# Altium schematic sheet BREEZE Pro ESC-A V1.SchDoc
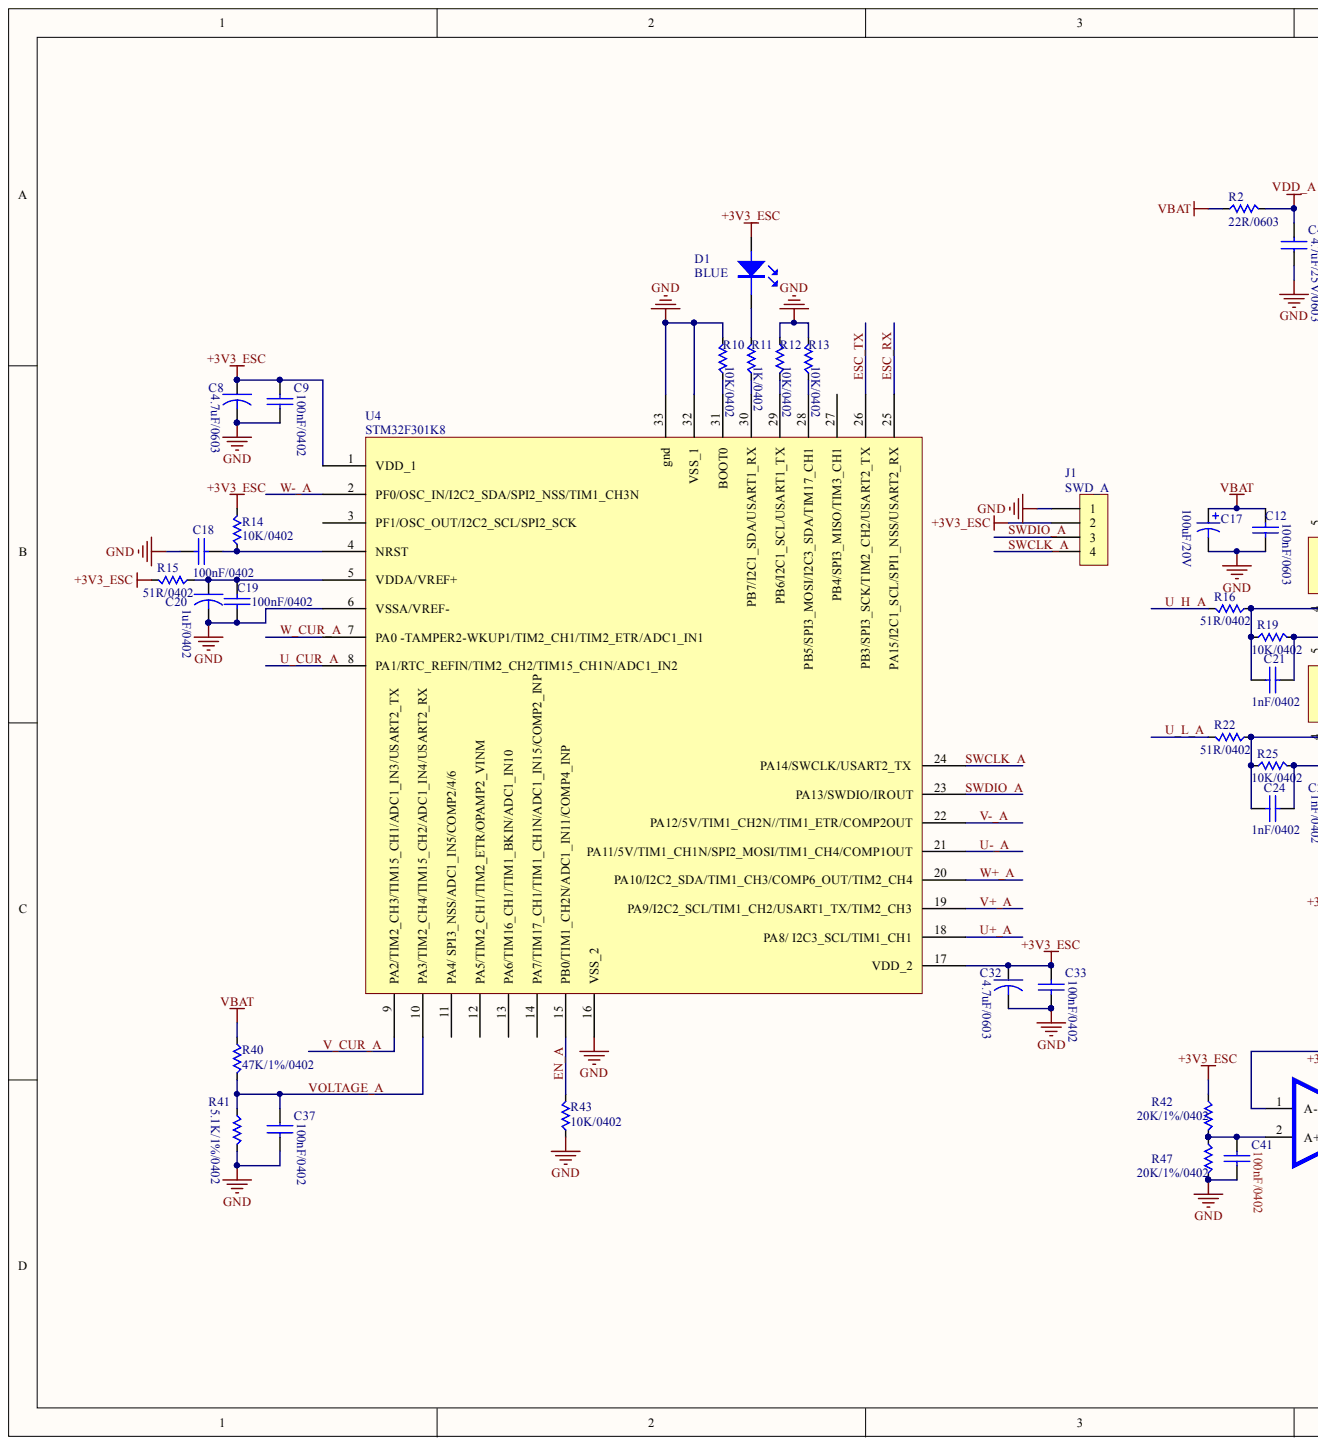
[diagram p0: BREEZE Pro ESC-A V1.SchDoc, left]
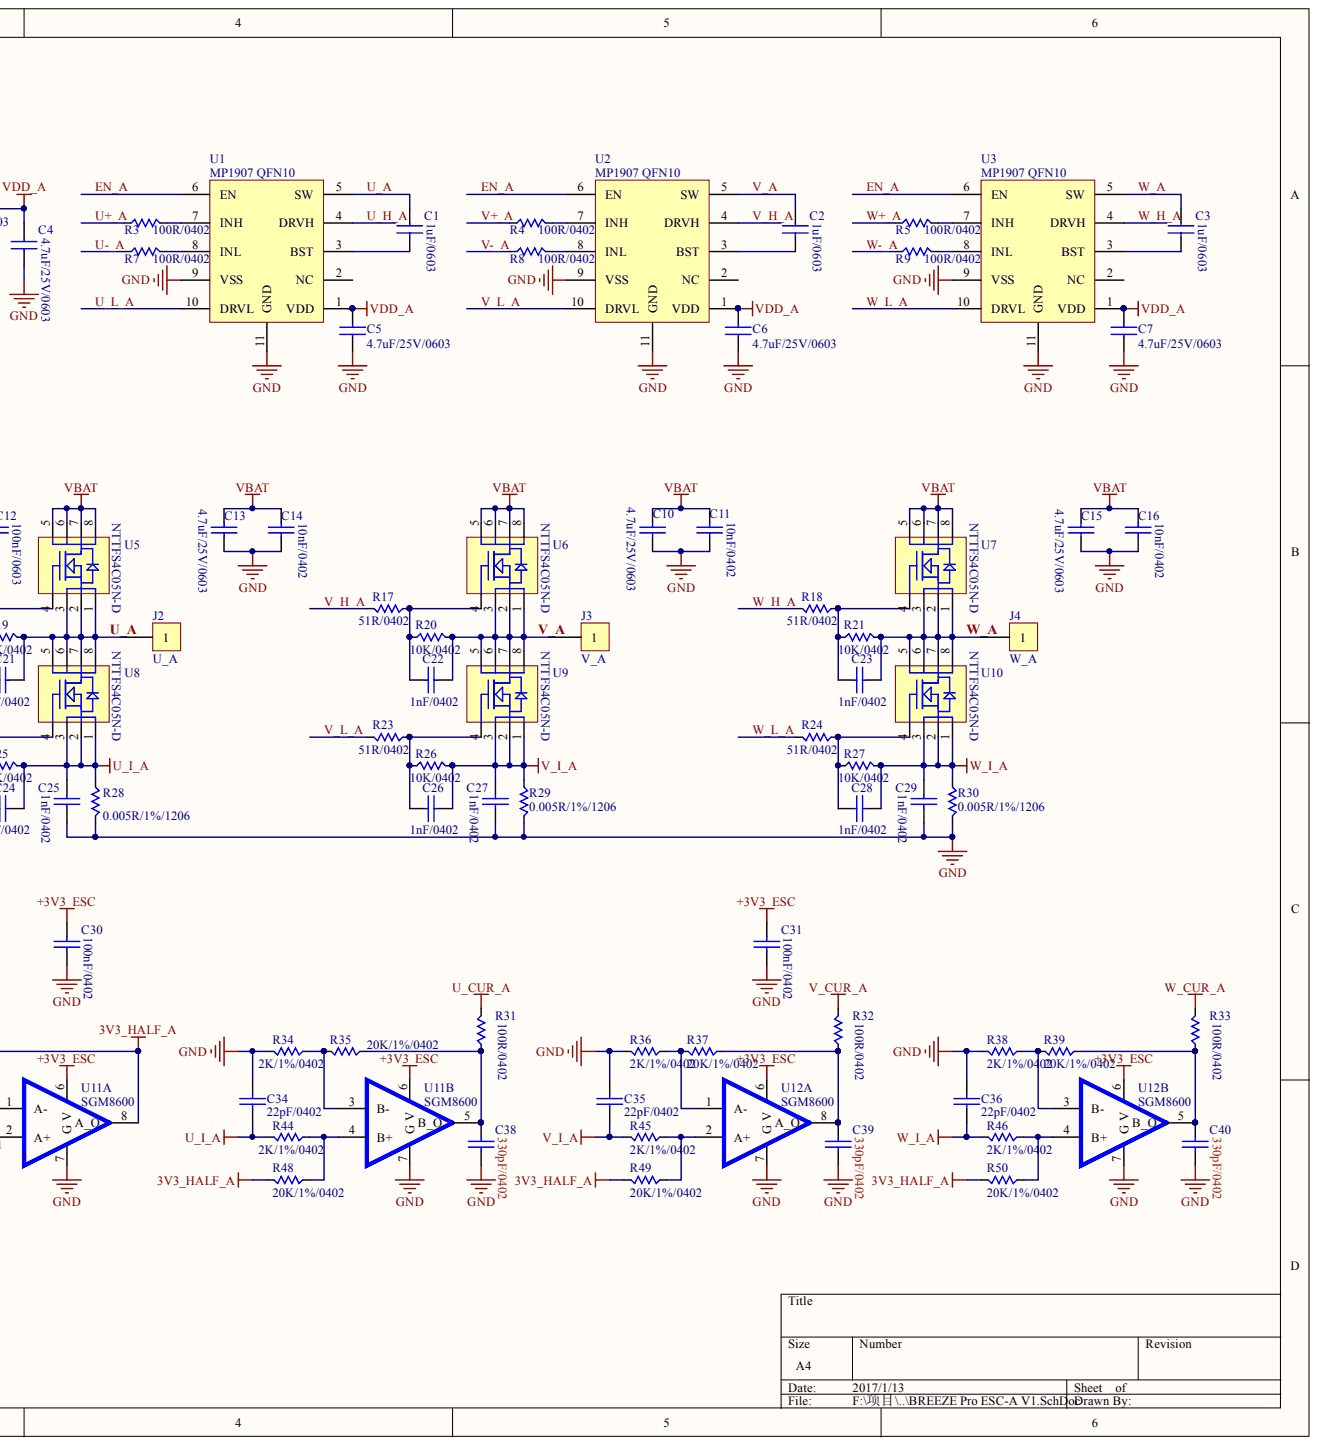
[diagram p1: BREEZE Pro ESC-A V1.SchDoc, right]

## Parts
C1  1uF/0603
C2  1uF/0603
C3  1uF/0603
C4  4.7uF/25V/0603
C5  4.7uF/25V/0603
C6  4.7uF/25V/0603
C7  4.7uF/25V/0603
C8  4.7uF/0603
C9  100nF/0402
C10  4.7uF/25V/0603
C11  10nF/0402
C12  100nF/0603
C13  4.7uF/25V/0603
C14  10nF/0402
C15  4.7uF/25V/0603
C16  10nF/0402
C17  100uF/20V
C18  100nF/0402
C19  100nF/0402
C20  1uF/0402
C21  1nF/0402
C22  1nF/0402
C23  1nF/0402
C24  1nF/0402
C25  1nF/0402
C26  1nF/0402
C27  1nF/0402
C28  1nF/0402
C29  1nF/0402
C30  100nF/0402
C31  100nF/0402
C32  4.7uF/0603
C33  100nF/0402
C34  22pF/0402
C35  22pF/0402
C36  22pF/0402
C37  100nF/0402
C38  330pF/0402
C39  330pF/0402
C40  330pF/0402
C41  100nF/0402
D1  BLUE
J1  SWD_A
J2  U_A
J3  V_A
J4  W_A
R2  22R/0603
R3  100R/0402
R4  100R/0402
R5  100R/0402
R7  100R/0402
R8  100R/0402
R9  100R/0402
R10  10K/0402
R11  1K/0402
R12  10K/0402
R13  10K/0402
R14  10K/0402
R15  51R/0402
R16  51R/0402
R17  51R/0402
R18  51R/0402
R19  10K/0402
R20  10K/0402
R21  10K/0402
R22  51R/0402
R23  51R/0402
R24  51R/0402
R25  10K/0402
R26  10K/0402
R27  10K/0402
R28  0.005R/1%/1206
R29  0.005R/1%/1206
R30  0.005R/1%/1206
R31  100R/0402
R32  100R/0402
R33  100R/0402
R34  2K/1%/0402
R35  20K/1%/0402
R36  2K/1%/0402
R37  20K/1%/0402
R38  2K/1%/0402
R39  20K/1%/0402
R40  47K/1%/0402
R41  5.1K/1%/0402
R42  20K/1%/0402
R43  10K/0402
R44  2K/1%/0402
R45  2K/1%/0402
R46  2K/1%/0402
R47  20K/1%/0402
R48  20K/1%/0402
R49  20K/1%/0402
R50  20K/1%/0402
U1  MP1907 QFN10
U2  MP1907 QFN10
U3  MP1907 QFN10
U4  STM32F301K8
U5  NTTFS4C05N-D
U6  NTTFS4C05N-D
U7  NTTFS4C05N-D
U8  NTTFS4C05N-D
U9  NTTFS4C05N-D
U10  NTTFS4C05N-D
U11  SGM8600  (parts A,B)
U12  SGM8600  (parts A,B)

## Nets
3V3_HALF_A: R48.2 R49.2 R50.2 U11.1(A-) U11.8(A_O)
+3V3_ESC: C8.1 C9.1 C30.1 C31.1 C32.1 C33.1 D1.1 J1.2 R14.2 R15.2 R42.1 U4.1(VDD_1) U4.17(VDD_2) U11.6(V) U12.6(V)
GND: C4.1 C5.1 C6.1 C7.1 C8.2 C9.2 C10.2 C11.2 C12.2 C13.2 C14.2 C15.2 C16.2 C17.2 C18.2 C19.2 C20.2 C25.2 C27.2 C29.2 C30.2 C31.2 C32.2 C33.2 C34.1 C35.1 C36.1 C37.2 C38.1 C39.1 C40.1 C41.1 J1.1 R10.2 R12.1 R13.2 R28.2 R29.2 R30.2 R34.2 R36.2 R38.2 R41.1 R43.1 R47.2 U1.9(VSS) U1.11(GND) U2.9(VSS) U2.11(GND) U3.9(VSS) U3.11(GND) U4.6(VSSA/VREF-) U4.16(VSS_2) U4.32(VSS_1) U4.33(gnd) U11.7(G) U12.7(G)
U_I_A: C24.2 C25.1 C34.2 R25.2 R28.1 R44.2 U8.1 U8.2 U8.3
V_I_A: C26.2 C27.1 C35.2 R26.2 R29.1 R45.2 U9.1 U9.2 U9.3
VBAT: C10.1 C11.1 C12.1 C13.1 C14.1 C15.1 C16.1 C17.1 R2.1 R40.2 U5.5 U5.6 U5.7 U5.8 U6.5 U6.6 U6.7 U6.8 U7.5 U7.6 U7.7 U7.8
VDD_A: C4.2 C5.2 C6.2 C7.2 R2.2 U1.1(VDD) U2.1(VDD) U3.1(VDD)
W_I_A: C28.2 C29.1 C36.2 R27.2 R30.1 R46.2 U10.1 U10.2 U10.3
EN_A: R43.2 U1.6(EN) U2.6(EN) U3.6(EN) U4.15(PB0/TIM1_CH2N/ADC1_IN11/COMP4_INP)
ESC_RX: U4.25(PA15/I2C1_SCL/SPI1_NSS/USART2_RX)
ESC_TX: U4.26(PB3/SPI3_SCK/TIM2_CH2/USART2_TX)
SWCLK_A: J1.4 U4.24(PA14/SWCLK/USART2_TX)
SWDIO_A: J1.3 U4.23(PA13/SWDIO/IROUT)
U+_A: R3.1 U4.18(PA8/_I2C3_SCL/TIM1_CH1)
U-_A: R7.1 U4.21(PA11/5V/TIM1_CH1N/SPI2_MOSI/TIM1_CH4/COMP1OUT)
U_A: C1.1 C21.2 J2.1 R19.2 U1.5(SW) U5.1 U5.2 U5.3 U8.5 U8.6 U8.7 U8.8
U_CUR_A: R31.2 U4.8(PA1/RTC_REFIN/TIM2_CH2/TIM15_CH1N/ADC1_IN2)
U_H_A: R16.2 U1.4(DRVH)
U_L_A: R22.2 U1.10(DRVL)
V+_A: R4.1 U4.19(PA9/I2C2_SCL/TIM1_CH2/USART1_TX/TIM2_CH3)
V-_A: R8.1 U4.22(PA12/5V/TIM1_CH2N//TIM1_ETR/COMP2OUT)
V_A: C2.1 C22.2 J3.1 R20.2 U2.5(SW) U6.1 U6.2 U6.3 U9.5 U9.6 U9.7 U9.8
V_CUR_A: R32.2 U4.9(PA2/TIM2_CH3/TIM15_CH1/ADC1_IN3/USART2_TX)
V_H_A: R17.2 U2.4(DRVH)
V_L_A: R23.2 U2.10(DRVL)
VOLTAGE_A: C37.1 R40.1 R41.2 U4.10(PA3/TIM2_CH4/TIM15_CH2/ADC1_IN4/USART2_RX)
W+_A: R5.1 U4.20(PA10/I2C2_SDA/TIM1_CH3/COMP6_OUT/TIM2_CH4)
W-_A: R9.1 U4.2(PF0/OSC_IN/I2C2_SDA/SPI2_NSS/TIM1_CH3N)
W_A: C3.1 C23.2 J4.1 R21.2 U3.5(SW) U7.1 U7.2 U7.3 U10.5 U10.6 U10.7 U10.8
W_CUR_A: R33.2 U4.7(PA0_-TAMPER2-WKUP1/TIM2_CH1/TIM2_ETR/ADC1_IN1)
W_H_A: R18.2 U3.4(DRVH)
W_L_A: R24.2 U3.10(DRVL)
NetC1_2: C1.2 U1.3(BST)
NetC2_2: C2.2 U2.3(BST)
NetC3_2: C3.2 U3.3(BST)
NetC18_1: C18.1 R14.1 U4.4(NRST)
NetC19_1: C19.1 C20.1 R15.1 U4.5(VDDA/VREF+)
NetC21_1: C21.1 R16.1 R19.1 U5.4
NetC22_1: C22.1 R17.1 R20.1 U6.4
NetC23_1: C23.1 R18.1 R21.1 U7.4
NetC24_1: C24.1 R22.1 R25.1 U8.4
NetC26_1: C26.1 R23.1 R26.1 U9.4
NetC28_1: C28.1 R24.1 R27.1 U10.4
NetC38_2: C38.2 R31.1 R35.1 U11.5(B_O)
NetC39_2: C39.2 R32.1 R37.1 U12.8(A_O)
NetC40_2: C40.2 R33.1 R39.1 U12.5(B_O)
NetC41_2: C41.2 R42.2 R47.1 U11.2(A+)
NetD1_2: D1.2 R11.1
NetR3_2: R3.2 U1.7(INH)
NetR4_2: R4.2 U2.7(INH)
NetR5_2: R5.2 U3.7(INH)
NetR7_2: R7.2 U1.8(INL)
NetR8_2: R8.2 U2.8(INL)
NetR9_2: R9.2 U3.8(INL)
NetR10_1: R10.1 U4.31(BOOT0)
NetR11_2: R11.2 U4.30(PB7/I2C1_SDA/USART1_RX)
NetR12_2: R12.2 U4.29(PB6/I2C1_SCL/USART1_TX)
NetR13_1: R13.1 U4.28(PB5/SPI3_MOSI/I2C3_SDA/TIM17_CH1)
NetR34_1: R34.1 R35.2 U11.3(22pF/0402)
NetR36_1: R36.1 R37.2 U12.1(A-)
NetR38_1: R38.1 R39.2 U12.3(22pF/0402)
NetR44_1: R44.1 R48.1 U11.4
NetR45_1: R45.1 R49.1 U12.2(A+)
NetR46_1: R46.1 R50.1 U12.4

Not connected: U1 2(NC); U2 2(NC); U3 2(NC); U4 3(PF1/OSC_OUT/I2C2_SCL/SPI2_SCK) 11(PA4/_SPI3_NSS/ADC1_IN5/COMP2/4/6) 12(PA5/TIM2_CH1/TIM2_ETR/OPAMP2_VINM) 13(PA6/TIM16_CH1/TIM1_BKIN/ADC1_IN10) 14(PA7/TIM17_CH1/TIM1_CH1N/ADC1_IN15/COMP2_INP) 27(PB4/SPI3_MISO/TIM3_CH1)

## Library data of the parts
C1-C7 C37 = (no symbol name): Text Field1: 20; Text Field2: 10
C8-C36 C38-C41 D1 J1-J4 R2-R5 R7-R50 U1-U12 = (no symbol name)
  C17: Published: 8-Jun-2000; LatestRevisionDate: 17-Jul-2002; LatestRevisionNote: Re-released for DXP Platform.; PackageReference: RB7.6-15; Publisher: Altium Limited
